# Altium schematic sheet AudioM_v1.0.SchDoc
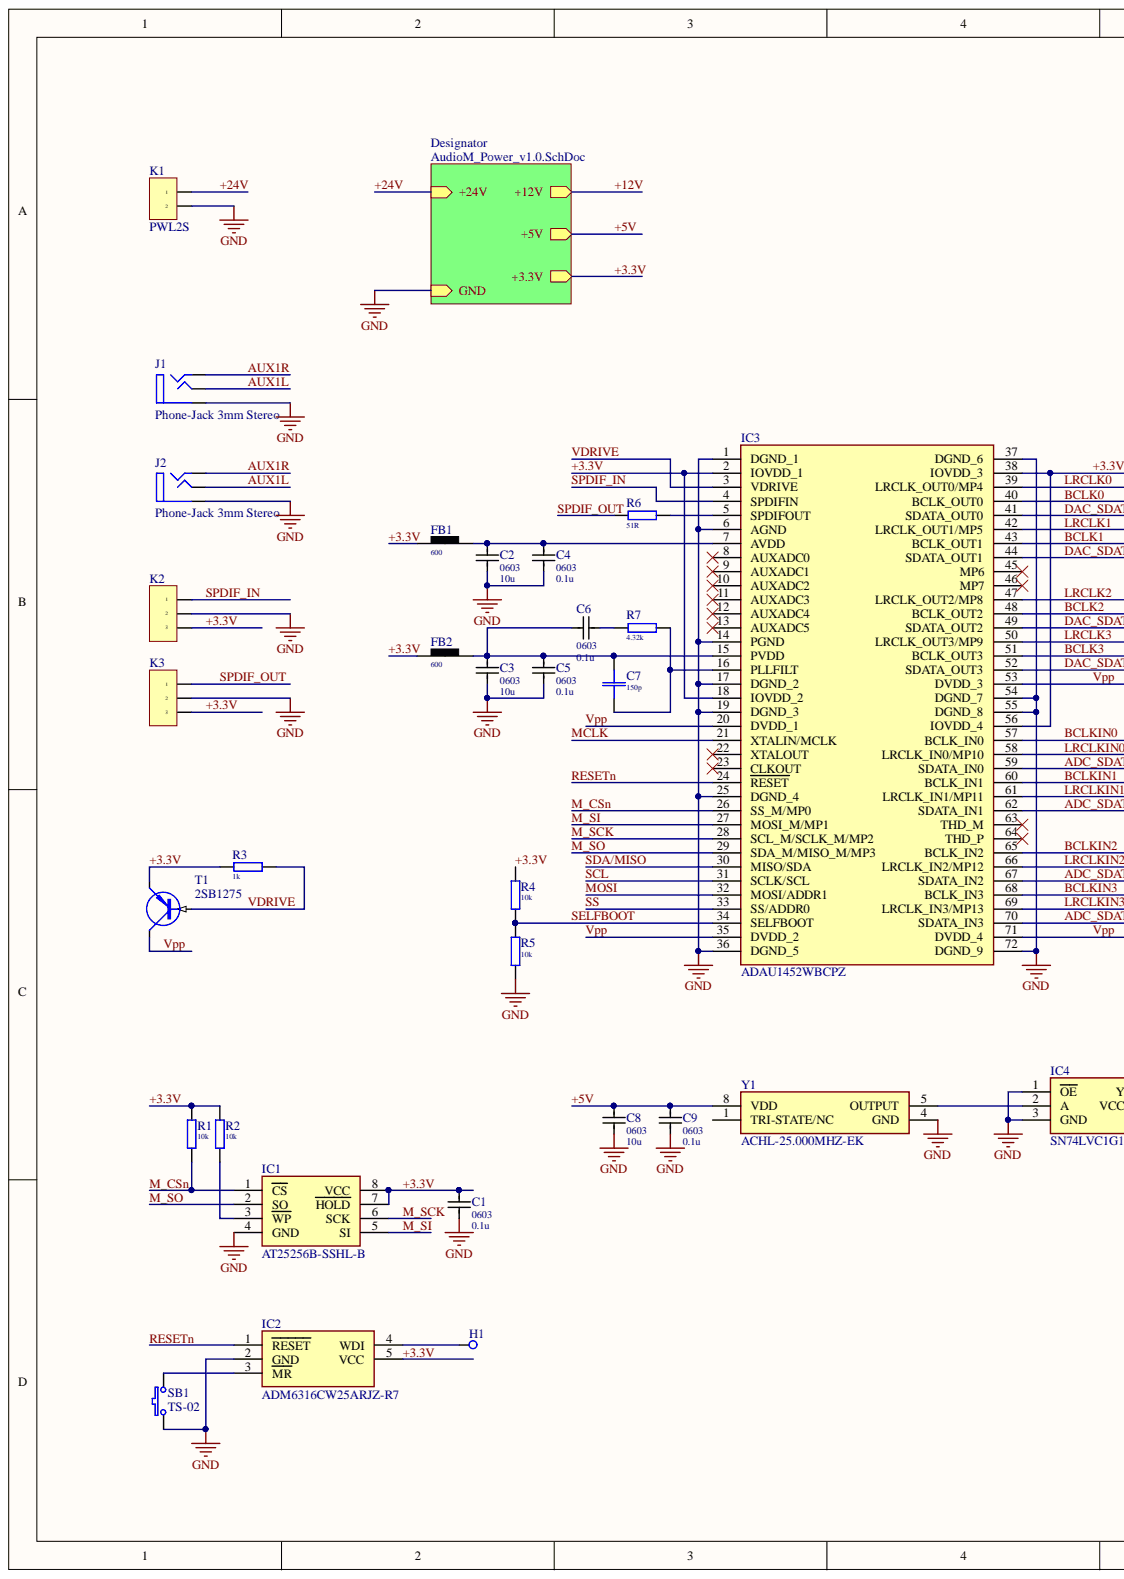
[diagram p0: AudioM_v1.0.SchDoc, left]
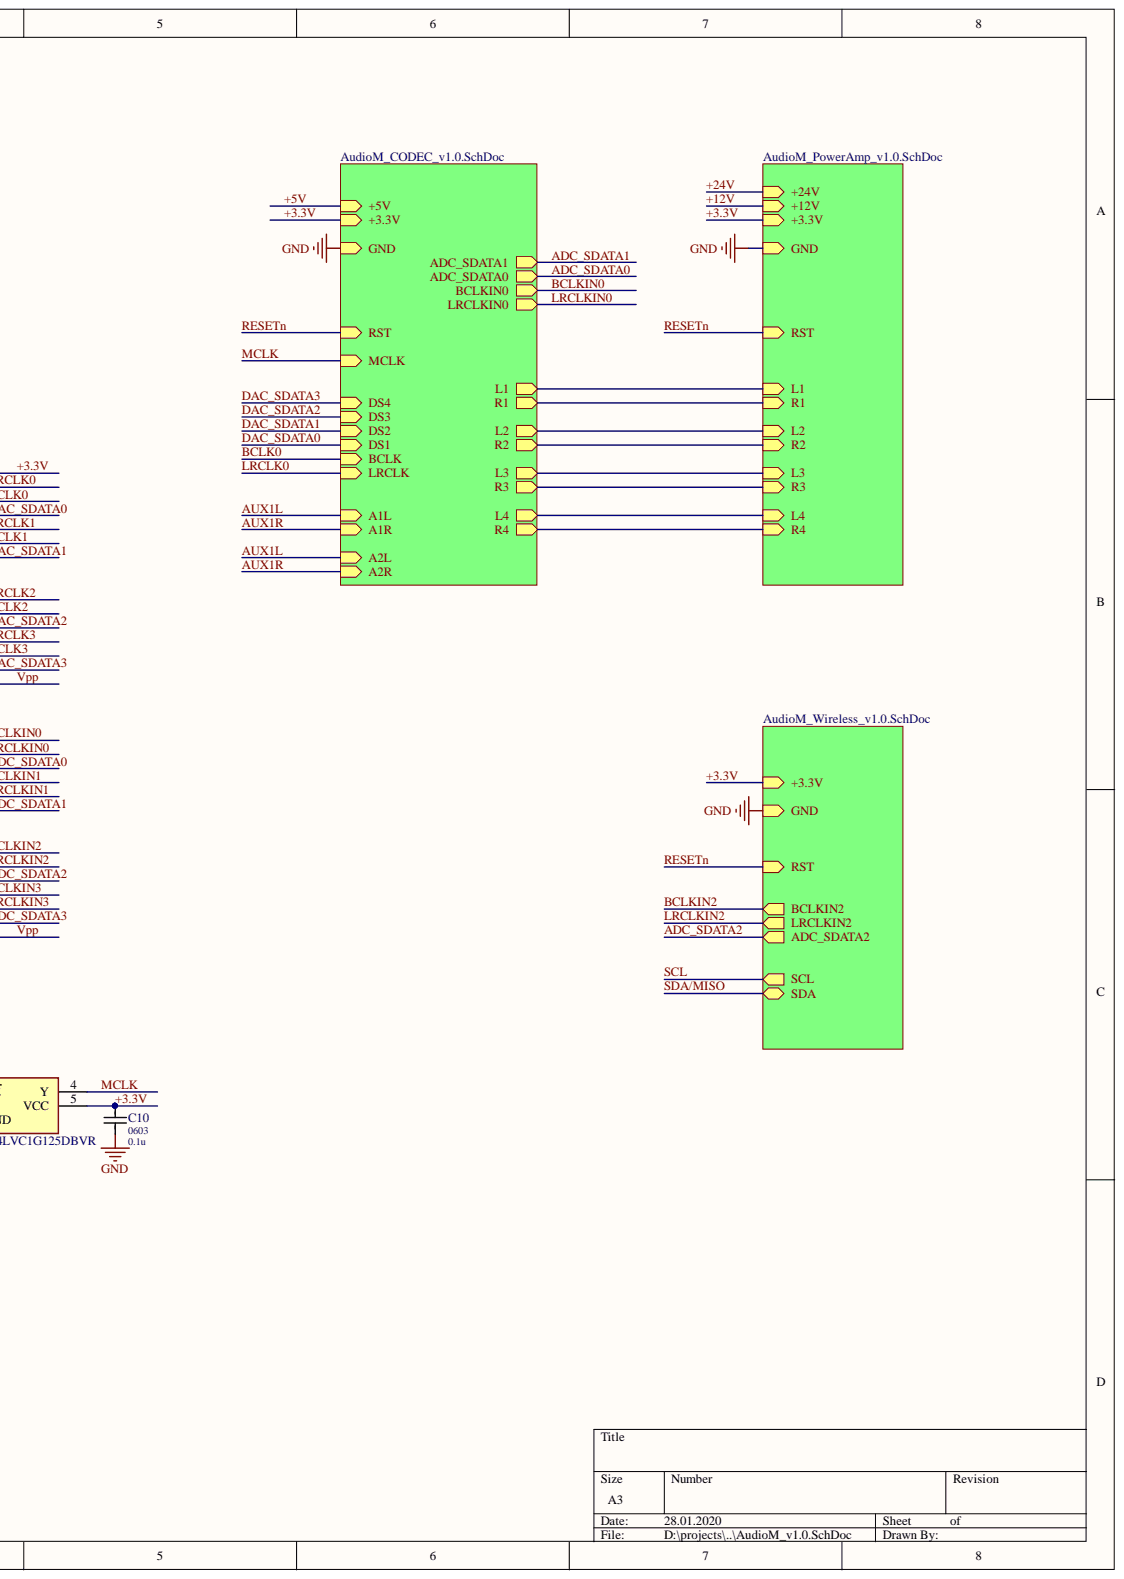
[diagram p1: AudioM_v1.0.SchDoc, right]

## Parts
C1  0603  value 0.1u  [CAPC1608N]
C2  0603  value 10u  [CAPC1608N]
C3  0603  value 10u  [CAPC1608N]
C4  0603  value 0.1u  [CAPC1608N]
C5  0603  value 0.1u  [CAPC1608N]
C6  0603  value 0.1u  [CAPC1608N]
C7  150p  [C0603]
C8  0603  value 10u  [CAPC1608N]
C9  0603  value 0.1u  [CAPC1608N]
C10  0603  value 0.1u  [CAPC1608N]
FB1  600  [FB0805]
FB2  600  [FB0805]
H1  H-1  [J1]
IC1  AT25256B-SSHL-B  [SOIC127P600X175-8N]
IC2  ADM6316CW25ARJZ-R7  [SOT95P280X145-5N]
IC3  ADAU1452WBCPZ  [72-LEAD-LFCSP_VQ]]
IC4  SN74LVC1G125DBVR  [SOT95P280X145-5N]
J1  Phone-Jack 3mm Stereo  [Jak-Ph St 12x12-1]
J2  Phone-Jack 3mm Stereo  [Jak-Ph St 12x12-1]
K1  PWL2S  [PWL2S]
K2  PWL3S  [PWL3S]  (value not drawn)
K3  PWL3S  [PWL3S]  (value not drawn)
R1  10k  [R0603]
R2  10k  [R0603]
R3  1k  [R0603]
R4  10k  [R0603]
R5  10k  [R0603]
R6  51R  [R0603]
R7  4.32k  [R0603]
SB1  TS-02  [TS-02]
T1  2SB1275  [TO252AA]
Y1  ACHL-25.000MHZ-EK  [ACHL]

## Nets
GND: C1.1 C2.1 C3.1 C4.1 C5.1 C8.1 C9.1 C10.1 IC1.4(GND) IC2.2(GND) IC3.1(DGND_1) IC3.6(AGND) IC3.14(PGND) IC3.17(DGND_2) IC3.19(DGND_3) IC3.25(DGND_4) IC3.36(DGND_5) IC3.37(DGND_6) IC3.54(DGND_7) IC3.55(DGND_8) IC3.72(DGND_9) IC4.1(OE) IC4.3(GND) J1.1 J2.1 K1.2 K2.2 K3.2 R5.2 SB1.1 Y1.4(GND)
+3.3V: C1.2 C10.2 FB1.1 FB2.1 IC1.7(HOLD) IC1.8(VCC) IC2.5(VCC) IC3.2(IOVDD_1) IC3.18(IOVDD_2) IC3.38(IOVDD_3) IC3.56(IOVDD_4) IC4.5(VCC) K2.3 K3.3 R1.1 R2.1 R3.2 R4.1 T1.3
+5V: C8.2 C9.2 Y1.8(VDD)
+24V: K1.1
ADC_SDATA0: IC3.59(SDATA_IN0)
ADC_SDATA1: IC3.62(SDATA_IN1)
ADC_SDATA2: IC3.67(SDATA_IN2)
ADC_SDATA3: IC3.70(SDATA_IN3)
AUX1L: J1.3 J2.3
AUX1R: J1.2 J2.2
BCLK0: IC3.40(BCLK_OUT0)
BCLK1: IC3.43(BCLK_OUT1)
BCLK2: IC3.48(BCLK_OUT2)
BCLK3: IC3.51(BCLK_OUT3)
BCLKIN0: IC3.57(BCLK_IN0)
BCLKIN1: IC3.60(BCLK_IN1)
BCLKIN2: IC3.65(BCLK_IN2)
BCLKIN3: IC3.68(BCLK_IN3)
DAC_SDATA0: IC3.41(SDATA_OUT0)
DAC_SDATA1: IC3.44(SDATA_OUT1)
DAC_SDATA2: IC3.49(SDATA_OUT2)
DAC_SDATA3: IC3.52(SDATA_OUT3)
LRCLK0: IC3.39(LRCLK_OUT0/MP4)
LRCLK1: IC3.42(LRCLK_OUT1/MP5)
LRCLK2: IC3.47(LRCLK_OUT2/MP8)
LRCLK3: IC3.50(LRCLK_OUT3/MP9)
LRCLKIN0: IC3.58(LRCLK_IN0/MP10)
LRCLKIN1: IC3.61(LRCLK_IN1/MP11)
LRCLKIN2: IC3.66(LRCLK_IN2/MP12)
LRCLKIN3: IC3.69(LRCLK_IN3/MP13)
M_CSn: IC1.1(CS) IC3.26(SS_M/MP0) R1.2
M_SCK: IC1.6(SCK) IC3.28(SCL_M/SCLK_M/MP2)
M_SI: IC1.5(SI) IC3.27(MOSI_M/MP1)
M_SO: IC1.2(SO) IC3.29(SDA_M/MISO_M/MP3)
MCLK: IC3.21(XTALIN/MCLK) IC4.4(Y)
MOSI: IC3.32(MOSI/ADDR1)
RESETn: IC2.1(RESET) IC3.24(RESET)
SCL: IC3.31(SCLK/SCL)
SDA/MISO: IC3.30(MISO/SDA)
SELFBOOT: IC3.34(SELFBOOT) R4.2 R5.1
SPDIF_IN: IC3.4(SPDIFIN) K2.1
SPDIF_OUT: K3.1 R6.2
SS: IC3.33(SS/ADDR0)
VDRIVE: IC3.3(VDRIVE) R3.1 T1.1
Vpp: IC3.20(DVDD_1) IC3.35(DVDD_2) IC3.53(DVDD_3) IC3.71(DVDD_4) T1.2
NetC2_2: C2.2 C4.2 FB1.2 IC3.7(AVDD)
NetC3_2: C3.2 C5.2 C6.2 C7.1 FB2.2 IC3.15(PVDD)
NetC6_1: C6.1 R7.2
NetC7_2: C7.2 IC3.16(PLLFILT) R7.1
NetH1_1: H1.1 IC2.4(WDI)
NetIC1_3: IC1.3(WP) R2.2
NetIC2_3: IC2.3(MR) SB1.2
NetIC3_5: IC3.5(SPDIFOUT) R6.1
NetIC4_2: IC4.2(A) Y1.5(OUTPUT)
+12V:
L1:
L2:
L3:
L4:
R1:
R2:
R3:
R4:

Not connected: IC3 8(AUXADC0) 9(AUXADC1) 10(AUXADC2) 11(AUXADC3) 12(AUXADC4) 13(AUXADC5) 22(XTALOUT) 23(CLKOUT) 45(MP6) 46(MP7) 63(THD_M) 64(THD_P); Y1 1(TRI-STATE/NC)

## Library data of the parts
C1-C5 C8-C10 = C0603: Component Kind: Standard; Library Name: PPK_v1.0.SCHLIB
C6 = C0603: Value: 0.1u; Component Kind: Standard; Library Name: PPK_v1.0.SCHLIB
C7 = C-0603: SMD-0603; Component Kind: Standard; Library Name: SMD-RCL.IntLib; Price: 0.014; Signal Integrity: Cap; Simulation: Capacitor; Value: 150p
FB1 FB2 = Chip FB BLM21P: 0805 1.5A 0.09ohm; Value: 0.51u; Component Kind: Standard; Library Name: SMD-RCL.IntLib; Price: 0.05; Signal Integrity: L; Simulation: Model Name
IC1 = AT25256B-SSHL-B: Integrated Circuit; Manufacturer_Name: Microchip; Component Kind: Standard; Datasheet Link: https://componentsearchengine.com/Datasheets/2/AT25256B-SSHL-B.pdf; Height: 1.75mm; Manufacturer_Part_Number: AT25256B-SSHL-B; Mouser Part Number: 556-AT25256B-SSHL-B; Mouser Price/Stock: https://www.mouser.com/Search/Refine.aspx?Keyword=556-AT25256B-SSHL-B; RS Part Number: 1274015P; RS Price/Stock: http://uk.rs-online.com/web/p/products/1274015P
IC2 = ADM6316CW25ARJZ-R7: Integrated Circuit; Manufacturer_Name: Analog Devices; Component Kind: Standard; Datasheet Link: http://componentsearchengine.com/Datasheets/1/ADM6316CW25ARJZ-R7.pdf; Height: 1.45mm; Manufacturer_Part_Number: ADM6316CW25ARJZ-R7; Mouser Part Number: 584-AM6316CW25ARJZR7; Mouser Price/Stock: https://www.mouser.com/Search/Refine.aspx?Keyword=584-AM6316CW25ARJZR7
IC3 = ADAU1452WBCPZ: Integrated Circuit; Manufacturer_Name: Analog Devices; Component Kind: Standard; Datasheet Link: https://componentsearchengine.com/Datasheets/1/ADAU1452WBCPZ.pdf; Manufacturer_Part_Number: ADAU1452WBCPZ; Mouser2 Part Number: 584-ADAU1452WBCPZ; Mouser2 Price/Stock: https://www.mouser.com/Search/Refine.aspx?Keyword=584-ADAU1452WBCPZ
IC4 = SN74LVC1G125DBVR: Integrated Circuit; Manufacturer_Name: Texas Instruments; Component Kind: Standard; Datasheet Link: http://www.ti.com/lit/ds/symlink/sn74lvc1g125.pdf; Height: 1.45mm; Manufacturer_Part_Number: SN74LVC1G125DBVR; Mouser Part Number: 595-SN74LVC1G125DBVR; Mouser Price/Stock: https://www.mouser.com/Search/Refine.aspx?Keyword=595-SN74LVC1G125DBVR; RS Part Number: 0528264; RS Price/Stock: http://uk.rs-online.com/web/p/products/0528264
K1 = PWL2S: 3.96mm 7A/250V; Component Kind: Standard; Library Name: Connektor.IntLib
K2 K3 = PWL3S: 3.96mm 7A/250V; Component Kind: Standard; Library Name: Connektor.IntLib; Price: 0.12
R1-R7 = R-0603: SMD 0603 0.0625W 5% 50V; Component Kind: Standard; Library Name: SMD-RCL.IntLib; Price: 0.017; Signal Integrity: Res; Simulation: Resistor
  R1 R2 R4 R5: Value: 10k
  R3: Value: 1k
  R6: Value: 51R
  R7: Value: 4.32k
SB1 = Buton TS-02: Component Kind: Standard; Library Name: Devise.IntLib; Price: 0.1
T1 = 2SB1275: 160V 1.5A 50MHz; Component Kind: Standard; Library Name: BJT-Power.IntLib; Price: 0.5; Signal Integrity: Q; Simulation: Q2SB1275
Y1 = ACHL-25.000MHZ-EK: Crystal or Oscillator; Manufacturer_Name: ABRACON; Component Kind: Standard; Datasheet Link: https://abracon.com/Oscillators/ACHL.pdf; Manufacturer_Part_Number: ACHL-25.000MHZ-EK; Mouser Part Number: 815-ACHL-25-EK; Mouser Price/Stock: https://www.mouser.com/Search/Refine.aspx?Keyword=815-ACHL-25-EK
H1 = H-1: Component Kind: Standard; Library Name: Connektor.IntLib; Price: 0.01
J1 J2 = Phone-Jack 3mm Stereo: Component Kind: Standard; Library Name: Connektor.IntLib
